# Altium schematic sheet MCU_S32K144.SchDoc
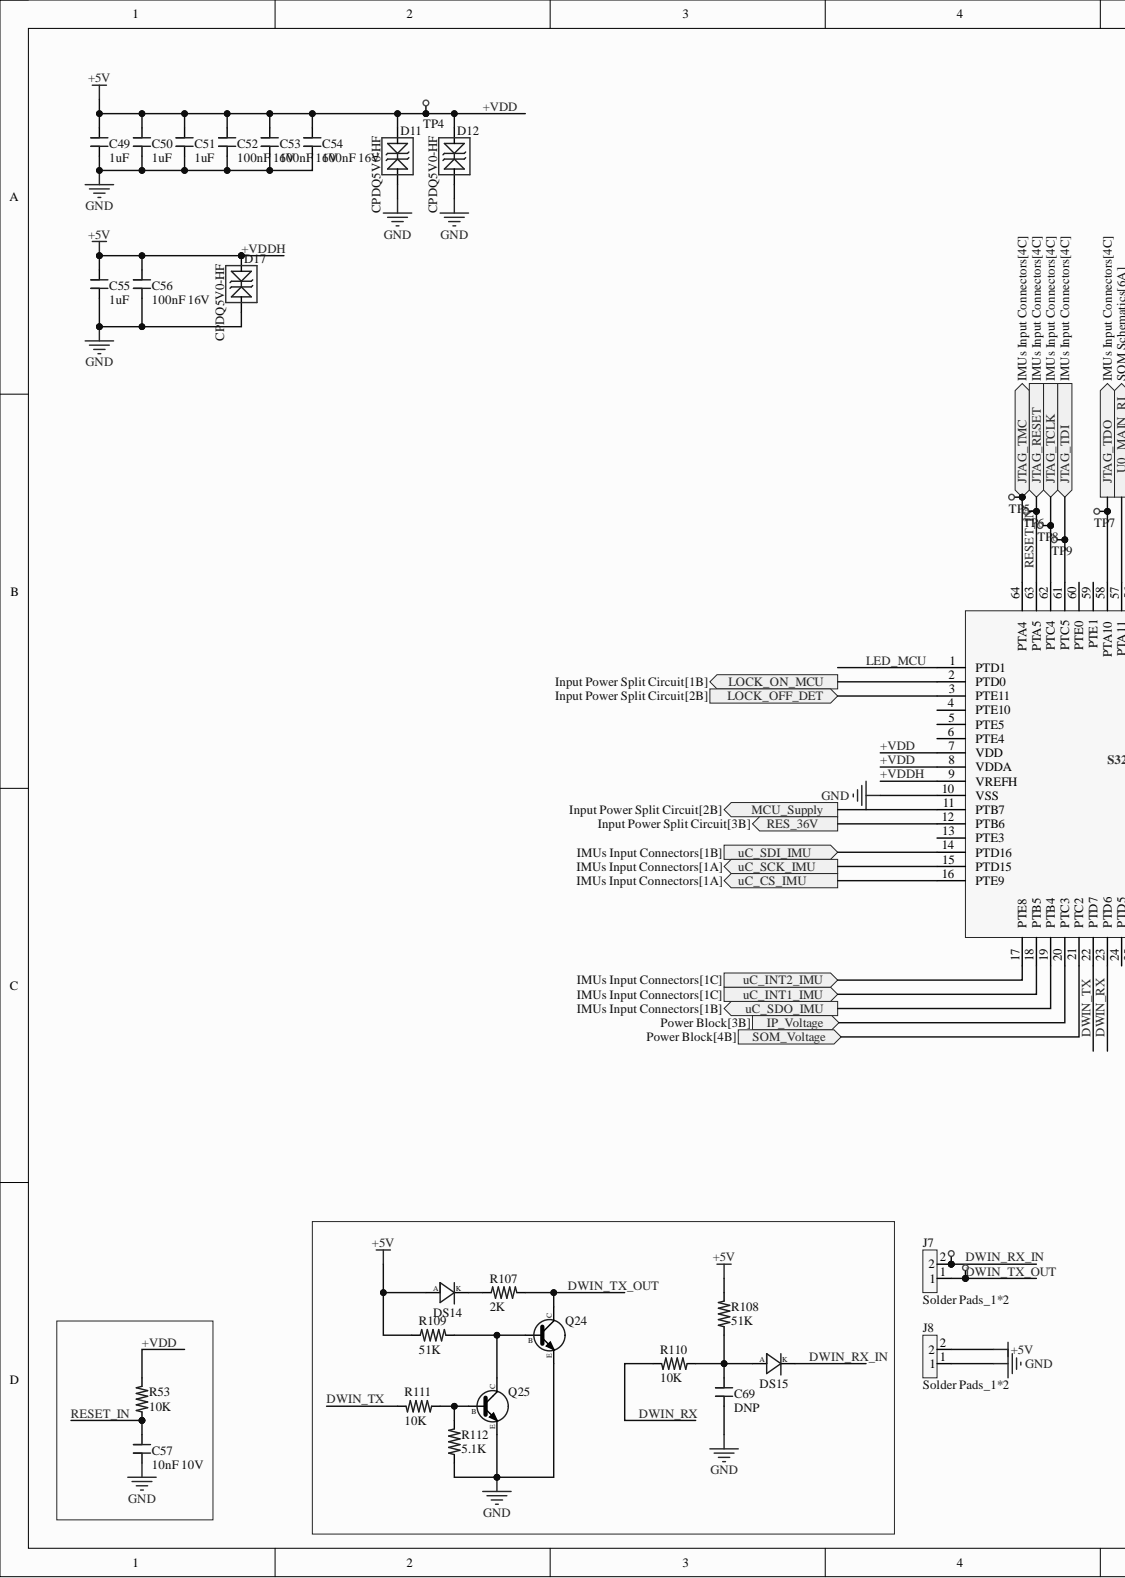
[diagram p0: MCU_S32K144.SchDoc, left]
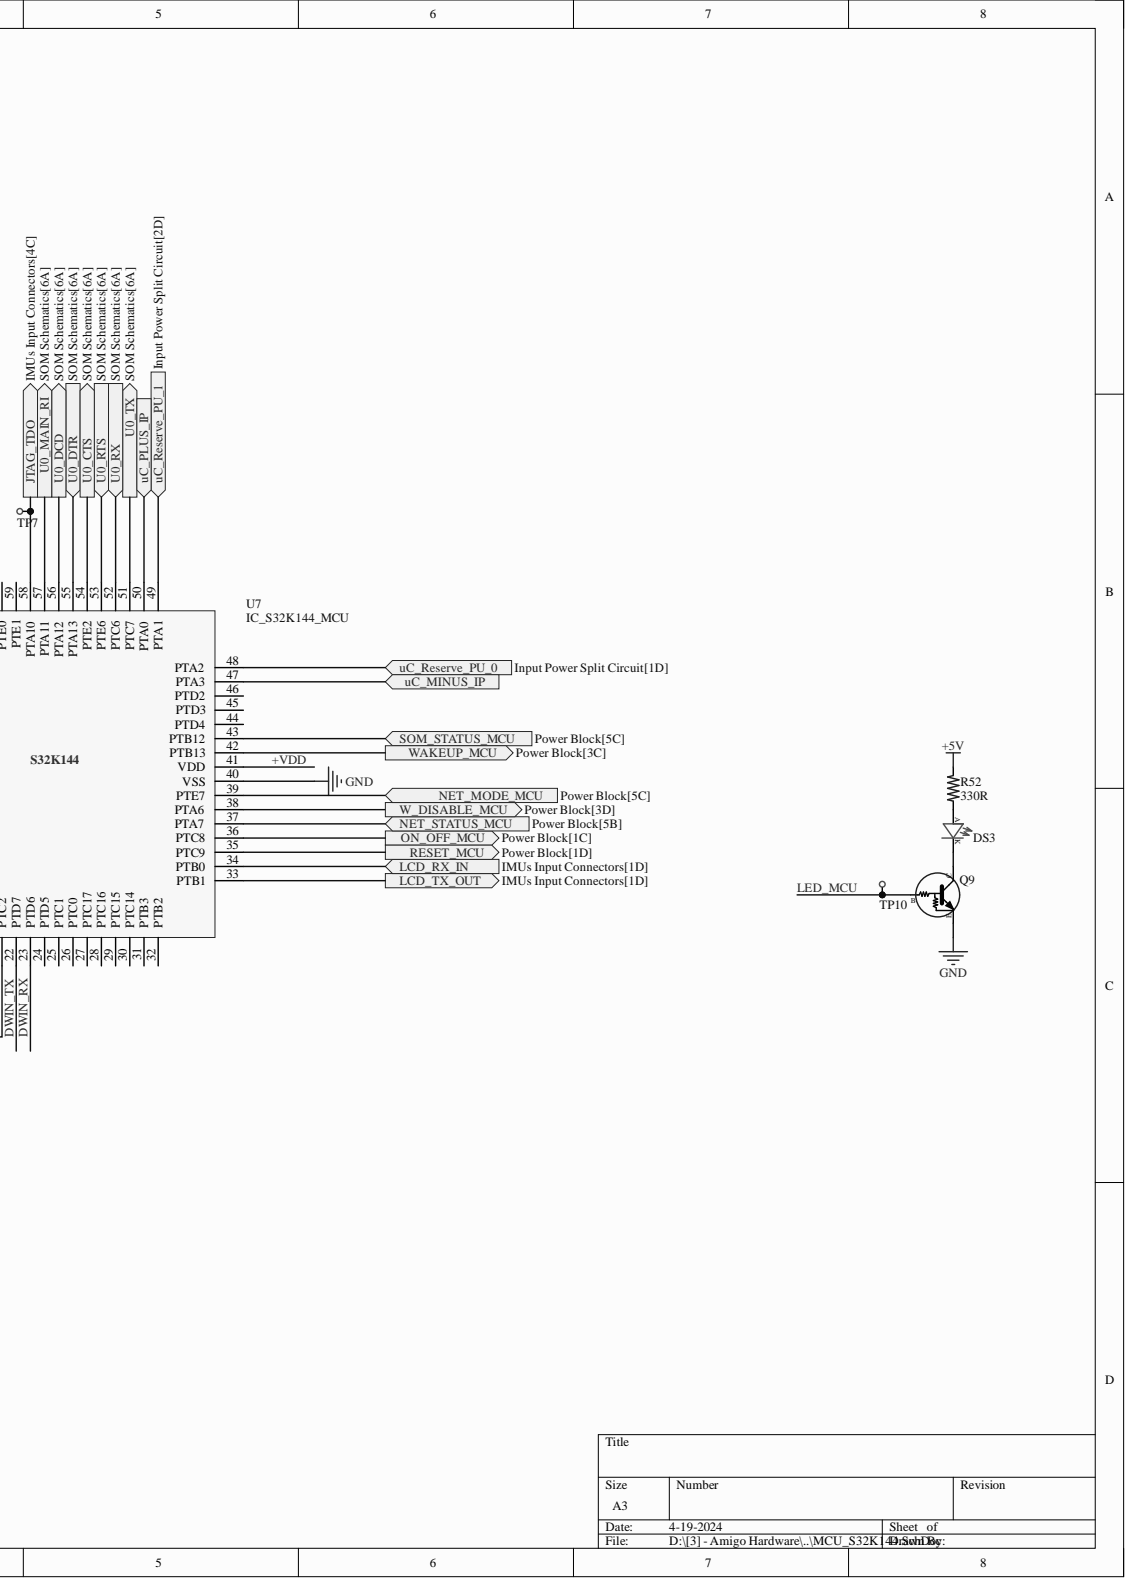
[diagram p1: MCU_S32K144.SchDoc, right]

## Parts
C49  1uF  [CAP_0603]
C50  1uF  [CAP_0603]
C51  1uF  [CAP_0603]
C52  100nF 16V  value 100nF  [CAP_0603]
C53  100nF 16V  value 100nF  Rated DC Voltage (URdc): 16V  [CAP_0603]
C54  100nF 16V  value 100nF  Rated DC Voltage (URdc): 16V  [CAP_0603]
C55  1uF  [CAP_0603]
C56  100nF 16V  value 100nF  [CAP_0603]
C57  10nF 10V  Capacitance: 10nF  Voltage Rating: 10V  [CAP_0603]
C69  DNP  [CAP_0603]  (no BOM)
D11  CPDQ5V0-HF  [SOD-923F]
D12  CPDQ5V0-HF  [SOD-923F]
D17  CPDQ5V0-HF  [SOD-923F]
DS3  HSMS-C190  [LED_0603]  (value not drawn)
DS14  BAS416-HF  [SOD-323]  (value not drawn)
DS15  BAS416-HF  [SOD-323]  (value not drawn)
J7  Solder Pads_1*2  [Solder Pads 2*1]
J8  Solder Pads_1*2  [Solder Pads 2*1]
Q9  DDTC143ZCA-7-F  [SOT23]  (value not drawn)
Q24  MMBT5551LT3G  [SOT23]  (value not drawn)
Q25  MMBT5551LT3G  [SOT23]  (value not drawn)
R52  330R  [RES_0603]
R53  10K  [RES_0603]
R107  2K  [RES_0603]
R108  51K  [RES_0603]
R109  51K  [RES_0603]
R110  10K  [RES_0603]
R111  10K  [RES_0603]
R112  5.1K  [RES_0603]
TP2  Test Point SMD Round 0.8mm  [Test Point Round 0.8mm]  (value not drawn)
TP3  Test Point SMD Round 0.8mm  [Test Point Round 0.8mm]  (value not drawn)
TP4  Test Point SMD Round 0.8mm  [Test Point Round 0.8mm]  (value not drawn)
TP5  Test Point SMD Round 1.27mm  [Test Point Round 1.27mm]  (value not drawn)
TP6  Test Point SMD Round 1.27mm  [Test Point Round 1.27mm]  (value not drawn)
TP7  Test Point SMD Round 1.27mm  [Test Point Round 1.27mm]  (value not drawn)
TP8  Test Point SMD Round 1.27mm  [Test Point Round 1.27mm]  (value not drawn)
TP9  Test Point SMD Round 1.27mm  [Test Point Round 1.27mm]  (value not drawn)
TP10  Test Point SMD Round 0.8mm  [Test Point Round 0.8mm]  (value not drawn)
U7  IC_S32K144_MCU  [LQFP_64]

## Ports
IP_Voltage, JTAG_RESET, JTAG_TCLK, JTAG_TDI, JTAG_TDO, JTAG_TMC, LCD_RX_IN, LCD_TX_OUT, LOCK_OFF_DET, LOCK_ON_MCU, MCU_Supply, NET_MODE_MCU, NET_STATUS_MCU, ON_OFF_MCU, RES_36V, RESET_MCU, SOM_STATUS_MCU, SOM_Voltage, U0_CTS, U0_DCD, U0_DTR, U0_MAIN_RI, U0_RTS, U0_RX, U0_TX, uC_CS_IMU, uC_INT1_IMU, uC_INT2_IMU, uC_MINUS_IP, uC_PLUS_IP, uC_Reserve_PU_0, uC_Reserve_PU_1, uC_SCK_IMU, uC_SDI_IMU, uC_SDO_IMU, W_DISABLE_MCU, WAKEUP_MCU

## Nets
GND: C49.1 C50.1 C51.1 C52.1 C53.1 C54.1 C55.1 C56.1 C57.1 C69.1 D11.1 D12.1 D17.1 J8.1 Q9.2 Q24.2 Q25.2 R112.1 U7.10(VSS) U7.40(VSS)
+VDD: C49.2 C50.2 C51.2 C52.2 C53.2 C54.2 C55.2 C56.2 D11.2 D12.2 D17.2 DS14.1 J8.2 R52.2 R53.2 R108.2 R109.1 TP4.1 U7.7(VDD) U7.8(VDDA) U7.9(VREFH) U7.41(VDD)
DWIN_RX: R110.2 U7.23(PTD6)
DWIN_RX_IN: DS15.2 J7.2 TP2.1
DWIN_TX: R111.2 U7.22(PTD7)
DWIN_TX_OUT: J7.1 Q24.3 R107.2 TP3.1
IP_Voltage: U7.20(PTC3) ; port IP_Voltage
JTAG_TCLK: TP8.1 U7.62(PTC4) ; port JTAG_TCLK
JTAG_TDI: TP9.1 U7.61(PTC5) ; port JTAG_TDI
JTAG_TDO: TP7.1 U7.58(PTA10) ; port JTAG_TDO
JTAG_TMC: TP5.1 U7.64(PTA4) ; port JTAG_TMC
LCD_RX_IN: U7.34(PTB0) ; port LCD_RX_IN
LCD_TX_OUT: U7.33(PTB1) ; port LCD_TX_OUT
LED_MCU: Q9.1 TP10.1 U7.1(PTD1)
LOCK_OFF_DET: U7.3(PTE11) ; port LOCK_OFF_DET
LOCK_ON_MCU: U7.2(PTD0) ; port LOCK_ON_MCU
MCU_Supply: U7.11(PTB7) ; port MCU_Supply
NET_MODE_MCU: U7.39(PTE7) ; port NET_MODE_MCU
NET_STATUS_MCU: U7.37(PTA7) ; port NET_STATUS_MCU
ON_OFF_MCU: U7.36(PTC8) ; port ON_OFF_MCU
RES_36V: U7.12(PTB6) ; port RES_36V
RESET_IN: C57.2 R53.1 TP6.1 U7.63(PTA5) ; port JTAG_RESET
RESET_MCU: U7.35(PTC9) ; port RESET_MCU
SOM_STATUS_MCU: U7.43(PTB12) ; port SOM_STATUS_MCU
SOM_Voltage: U7.21(PTC2) ; port SOM_Voltage
U0_CTS: U7.54(PTE2) ; port U0_CTS
U0_DCD: U7.56(PTA12) ; port U0_DCD
U0_DTR: U7.55(PTA13) ; port U0_DTR
U0_MAIN_RI: U7.57(PTA11) ; port U0_MAIN_RI
U0_RTS: U7.53(PTE6) ; port U0_RTS
U0_RX: U7.52(PTC6) ; port U0_RX
U0_TX: U7.51(PTC7) ; port U0_TX
uC_CS_IMU: U7.16(PTE9) ; port uC_CS_IMU
uC_INT1_IMU: U7.18(PTB5) ; port uC_INT1_IMU
uC_INT2_IMU: U7.17(PTE8) ; port uC_INT2_IMU
uC_MINUS_IP: U7.47(PTA3) ; port uC_MINUS_IP
uC_PLUS_IP: U7.50(PTA0) ; port uC_PLUS_IP
uC_Reserve_PU_0: U7.48(PTA2) ; port uC_Reserve_PU_0
uC_Reserve_PU_1: U7.49(PTA1) ; port uC_Reserve_PU_1
uC_SCK_IMU: U7.15(PTD15) ; port uC_SCK_IMU
uC_SDI_IMU: U7.14(PTD16) ; port uC_SDI_IMU
uC_SDO_IMU: U7.19(PTB4) ; port uC_SDO_IMU
W_DISABLE_MCU: U7.38(PTA6) ; port W_DISABLE_MCU
WAKEUP_MCU: U7.42(PTB13) ; port WAKEUP_MCU
NetC69_2: C69.2 DS15.1 R108.1 R110.1
NetDS3_1: DS3.1 R52.1
NetDS3_2: DS3.2 Q9.3
NetDS14_2: DS14.2 R107.1
NetQ24_1: Q24.1 Q25.3 R109.2
NetQ25_1: Q25.1 R111.1 R112.2

Not connected: U7 4(PTE10) 5(PTE5) 6(PTE4) 13(PTE3) 24(PTD5) 25(PTC1) 26(PTC0) 27(PTC17) 28(PTC16) 29(PTC15) 30(PTC14) 31(PTB3) 32(PTB2) 44(PTD4) 45(PTD3) 46(PTD2) 59(PTE1) 60(PTE0)

## Library data of the parts
C49-C51 C55 = CAP_1uF-16V-10%-0603: Multilayer Ceramic Capacitor, 1 uF, 16 V, ± 10%, X7R, 0603 [1608 Metric]; Manufacturer: Yageo; Manufacturer Part Number: CC0603KRX7R7BB105; Dissipation Factor: 5%; Voltage: 16V; Capacitance: 1uF; Case Code (Imperial): 0603; Case Code (Metric): 1608; Case/Package: 0603; Category: Ceramic Capacitors; Component Kind: Standard; ComponentLink1Description: https://datasheet.ciiva.com/8033/1734573-8033801.pdf?src-supplier=Element14; ComponentLink1URL: https://datasheet.ciiva.com/8033/1734573-8033801.pdf?src-supplier=Element14; ComponentLink2Description: https://datasheet.ciiva.com/8033/1734573-8033800.pdf?src-supplier=Element14; ComponentLink2URL: https://datasheet.ciiva.com/8033/1734573-8033800.pdf?src-supplier=Element14; ComponentLink3Description: https://datasheet.ciiva.com/7978/1489800-7978143.pdf?src-supplier=Element14; ComponentLink3URL: https://datasheet.ciiva.com/7978/1489800-7978143.pdf?src-supplier=Element14; ComponentLink4Description: https://datasheet.ciiva.com/28450/1489800-28450557.pdf?src-supplier=Element14; ComponentLink4URL: https://datasheet.ciiva.com/28450/1489800-28450557.pdf?src-supplier=Element14; ComponentLink5Description: https://datasheet.ciiva.com/176/mlccorderingcode-176789.pdf?src-supplier=Digi-Key; ComponentLink5URL: https://datasheet.ciiva.com/176/mlccorderingcode-176789.pdf?src-supplier=Digi-Key; ComponentLink6Description: https://datasheet.ciiva.com/176/mlccorderingcode-176788.pdf?src-supplier=Digi-Key; ComponentLink6URL: https://datasheet.ciiva.com/176/mlccorderingcode-176788.pdf?src-supplier=Digi-Key; ComponentLink7Description: https://datasheet.ciiva.com/176/mlccorderingcode-176790.pdf?src-supplier=Digi-Key; ComponentLink7URL: https://datasheet.ciiva.com/176/mlccorderingcode-176790.pdf?src-supplier=Digi-Key; ComponentLink8Description: https://datasheet.ciiva.com/5425/upy-gphc-x7r-6-3v-to-50v-8-12304-5425495.pdf?src-supplier=Mouser; ComponentLink8URL: https://datasheet.ciiva.com/5425/upy-gphc-x7r-6-3v-to-50v-8-12304-5425495.pdf?src-supplier=Mouser; Construction: Flat; Depth: 0.8mm; Dielectric: X7R; Halogen Free: Halogen Free; Height: 0.8mm; Lead Free: Lead Free; Length: 1.6mm; Library Name: EM_LIBRARY_1.IntLib; Manufacturer 1: Yageo; Manufacturer Part Number 1: CC0603KRX7R7BB105; Max Operating Temperature: 125°C; Min Operating Temperature: -55°C; Mount: Surface Mount; Number of Pins: 2; Package Quantity: 1; Packaging: Tape and Reel; Pricing 1: 1=0.14, 10=0.098, 50=0.0556, 100=0.0461, 500=0.03234, 1000=0.02678 (USD); Radiation Hardening: No; Reach SVHC Compliant: Unknown; RoHS: Yes; RoHS Compliant: Yes; Series: CC; Stock 1: 9958482; Supplier 1: Digi-Key; Supplier-1: Digikey; Termination: SMD/SMT; Thickness: 0.035inch; Tolerance: 10%; Voltage Rating: 16V; Voltage Rating (DC): 16V; Width: 0.031inch
C52-C54 C56 = CAP_100nF_16V_10%_X7R_0603: Ceramic Capacitor, Multilayer, Ceramic, 16V, 10% +Tol, 10% -Tol, X7R, 15% TC, 0.1uF, Surface Mount, 0603; Manufacturer: KEMET; Manufacturer Part Number: C0603C104K4RACTU; Multilayer: Yes; Capacitor Type: Ceramic; Case/Package: 0603; Category: Ceramic Capacitors; Component Kind: Standard; ComponentLink1Description: https://datasheet.ciiva.com:443/pdfs/VipMasterIC/IC/KEME/KEME-S-A0008991654/KEME-S-A0008991654-1.pdf?src-supplier=IHS+Markit; ComponentLink1URL: https://datasheet.ciiva.com:443/pdfs/VipMasterIC/IC/KEME/KEME-S-A0008991654/KEME-S-A0008991654-1.pdf?src-supplier=IHS+Markit; ComponentLink2Description: https://datasheet.ciiva.com/22749/kem-c1002-x7r-smd-22749657.pdf?src-supplier=Element14; ComponentLink2URL: https://datasheet.ciiva.com/22749/kem-c1002-x7r-smd-22749657.pdf?src-supplier=Element14; ComponentLink3Description: https://datasheet.ciiva.com/14589/70095383-14589014.pdf?src-supplier=Allied+Electronics; ComponentLink3URL: https://datasheet.ciiva.com/14589/70095383-14589014.pdf?src-supplier=Allied+Electronics; ComponentLink4Description: https://datasheet.ciiva.com/5413/kem-c1002-x7r-smd-5413791.pdf?src-supplier=Mouser; ComponentLink4URL: https://datasheet.ciiva.com/5413/kem-c1002-x7r-smd-5413791.pdf?src-supplier=Mouser; Dielectric Material: Ceramic; Height: 0.8mm; JESD-609 Code: e3; Length: 1.6mm; Library Name: EM_LIBRARY_1.IntLib; Max Operating Temperature: 125°C; Min Operating Temperature: -55°C; Mounting Technology: Surface Mount; Package Shape: Rectangular; Package Style: SMTMeter; Packaging: Tape and Reel; Pins: 2; Pricing: 1=0.1, 10=0.069, 50=0.0394, 100=0.0305, 500=0.02298, 1000=0.01805 (USD); Reach SVHC Compliant: Yes; RoHS: Yes; RoHS Compliant: Yes; Stock: 507245; Supplier: Digi-Key; Supplier Part Number: 399-C0603C104K4RAC7867DKR-ND; Temperature Characteristics Code: X7R; Terminal Finish: Nickel; Termination: Wraparound; Tolerance  (fill in as +-): 10%; Tolerance (fill in as +-): 10%; Width: 0.8mm
  C52 C56: Rated DC Voltage (URdc): 16V
C57 = CAP_10nF_10V_10%_X7R_0603: Multilayer Ceramic Capacitor, 0.01 uF, 10 V, ± 10%, X7R, 0603 [1608 Metric]; Manufacturer: KEMET; Manufacturer Part Number: C0603C103K8RACTU; Dissipation Factor: 5%; Case Code (Imperial): 0603; Case Code (Metric): 1608; Case/Package: 0603; Category: Ceramic Capacitors; Component Kind: Standard; ComponentLink1Description: https://datasheet.ciiva.com/49516/1564916-49516523.pdf?src-supplier=Jameco; ComponentLink1URL: https://datasheet.ciiva.com/49516/1564916-49516523.pdf?src-supplier=Jameco; Construction: Flat; Depth: 0.8mm; Dielectric: X7R; Dielectric Material: Ceramic; Height: 0.8mm; Lead Pitch: 0.7mm; Length: 1.6mm; Library Name: EM_LIBRARY_1.IntLib; Manufacturer 1: KEMET; Manufacturer Part Number 1: C0603C103K8RACTU; Max Operating Temperature: 125°C; Min Operating Temperature: -55°C; Mount: Surface Mount; Package Quantity: 1; Packaging: Tape and Reel; Pricing 1: 1=0.1, 10=0.034, 50=0.034, 100=0.02, 1000=0.016, 10000=0.011 (USD); Reach SVHC Compliant: No; RoHS: Yes; Series: -; Stock 1: 6134; Supplier 1: Mouser; Supplier Part Number 1: 80-C0603C103K8R; Supplier-1: Digikey; Termination: SMD/SMT; Thickness: 0.034inch; Tolerance: 10%; Voltage Rating (DC): 10V; Width: 0.031inch
C69 = CAP_NOT-MOUNTED_0603: Do Not Place this Capacitor 0603; Manufacturer: KEMET; Manufacturer Part Number: C0603C103K8RACTU; Capacitance: 10nF; Dielectric: X7R; Dielectric Material: Ceramic; Dissipation Factor: 5%; Tolerance: 10%; Voltage Rating: 10V; Case Code (Imperial): 0603; Case Code (Metric): 1608; Case/Package: 0603; Category: Ceramic Capacitors; ComponentLink1Description: https://datasheet.ciiva.com/49516/1564916-49516523.pdf?src-supplier=Jameco; ComponentLink1URL: https://datasheet.ciiva.com/49516/1564916-49516523.pdf?src-supplier=Jameco; Construction: Flat; Depth: 0.8mm; Height: 0.8mm; Lead Pitch: 0.7mm; Length: 1.6mm; Library Name: EM_LIBRARY_1.IntLib; Manufacturer 1: KEMET; Manufacturer Part Number 1: C0603C103K8RACTU; Max Operating Temperature: 125°C; Min Operating Temperature: -55°C; Mount: Surface Mount; Package Quantity: 1; Packaging: Tape and Reel; Pricing 1: 1=0.1, 10=0.034, 50=0.034, 100=0.02, 1000=0.016, 10000=0.011 (USD); Reach SVHC Compliant: No; RoHS: Yes; Series: -; Stock 1: 6134; Supplier 1: Mouser; Supplier Part Number 1: 80-C0603C103K8R; Supplier-1: Digikey; Termination: SMD/SMT; Thickness: 0.034inch; Voltage Rating (DC): 10V; Width: 0.031inch
D11 D12 D17 = DIO-TVS_5.6V Vbr_18.6V Vc_9.4A Ip_SOD-923: ESD Suppressor Diode TVS Bi-Dir 5V 18.6Vc 2-Pin SOD-923F T/R; Manufacturer: Comchip; Breakdown Voltage: 5.6V; Capacitance: 15pF; Clamping Voltage: 7.3V; Diode Type: ZENER; Number of Bidirectional Channels: 1; Peak Pulse Current: 9.4A; Peak Pulse Power: 174W; Power Line Protection: No; Case/Package: 0402; Category: TVS Diodes; Component Kind: Standard; ComponentLink1Description: https://datasheet.ciiva.com/6577/cpdq5v0-hf20reva825126-6577872.pdf?src-supplier=Digi-Key; ComponentLink1URL: https://datasheet.ciiva.com/6577/cpdq5v0-hf20reva825126-6577872.pdf?src-supplier=Digi-Key; ComponentLink2Description: https://datasheet.ciiva.com/12545/cpdq5v0-hf20reva825126-218795-12545530.pdf?src-supplier=Mouser; ComponentLink2URL: https://datasheet.ciiva.com/12545/cpdq5v0-hf20reva825126-218795-12545530.pdf?src-supplier=Mouser; Library Name: EM_LIBRARY_1.IntLib; Manufacturer 2: Comchip; Manufacturer Part Number: CPDQ5V0-HF; Manufacturer Part Number 2: CPDQ5V0-HF; Max Operating Temperature: 125°C; Min Breakdown Voltage: 5.6V; Min Operating Temperature: -40°C; Mount: Surface Mount; Operating Supply Voltage: 5V; Package Quantity: 8000; Packaging: Tape and Reel; Pricing 1: 1=0.31, 10=0.252, 100=0.1337, 500=0.08794, 1000=0.0598, 2000=0.05394 (USD); Pricing 2: 1=0.31, 10=0.214, 100=0.1042, 500=0.08692, 1000=0.0604, 2000=0.05235 (USD); Reverse Standoff Voltage: 5V; RoHS: Yes; RoHS Compliant: Yes; Series: -; Stock 1: 81421; Stock 2: 80696; Supplier 1: Digi-Key; Supplier 2: Digi-Key; Supplier Part Number 2: 641-1478-1-ND
DS3 = LED_Red_0603_2.2V_HSMS-C190: LED Low-Power Uni-Color Red 630nm 2.1V 2-Pin Chip LED T/R; Manufacturer: Broadcom Avago; Category: LEDs; Component Kind: Standard; Library Name: EM_LIBRARY_1.IntLib; Manufacturer Part Number: HSMS-C190; Pricing 1: 1=0.41, 10=0.272, 100=0.1813, 500=0.12542, 1000=0.10452, 2000=0.09407 (USD); RoHS: Yes; Stock 1: 190765; Supplier 1: Digi-Key; Supplier Part Number 1: 516-1422-1-ND
DS14 DS15 = DIO_REC_100V_200mA_SOD-323: Diode Switching 85V 200MA 250MW; Manufacturer: Comchip; Component Kind: Standard; Library Name: EM_LIBRARY_1.IntLib; Manufacturer Part Number: BAS416-HF; Pricing: 1=0.21, 10=0.146, 100=0.0711, 500=0.0593, 1000=0.04121 (USD); Stock: 2730; Supplier: DigiKey; Supplier Part Number: 641-BAS416-HFCT-ND
J7 J8 = Solder Pads_1*2: Solder Pads Pos 2; Component Kind: Net Tie; Library Name: EM_LIBRARY_1.IntLib
Q9 = TRANS-NPN-BIAS_50V_100mA_250MHz_200mW_SOT23-3: Small Signal Bipolar Transistor, 0.1A I(C), 50V V(BR)CEO, 1-Element, NPN, Silicon; Manufacturer: Diodes; DC Current Gain-Min (hFE): 80; Frequency: 250MHz; Max Collector Current: 100mA; Number of Elements: 1; Package Body Material: Plastic; Power Dissipation-Max (Abs): 200mW; Qualification Status: Not Qualified; Time @ Peak Reflow Temperature-Max (s): 40s; Category: BJTs; Collector-emitter Voltage-Max: 50V; Component Kind: Standard; ComponentLink1Description: https://datasheet.ciiva.com:443/pdfs/VipMasterIC/IC/DIOD/DIODS20605/DIODS20605-1.pdf?src-supplier=IHS+Markit; ComponentLink1URL: https://datasheet.ciiva.com:443/pdfs/VipMasterIC/IC/DIOD/DIODS20605/DIODS20605-1.pdf?src-supplier=IHS+Markit; ComponentLink2Description: http://components.arrow.com/part/dataview/41508542S9348013N2867?src-supplier=Arrow+Electronics; ComponentLink2URL: http://components.arrow.com/part/dataview/41508542S9348013N2867?src-supplier=Arrow+Electronics; ComponentLink3Description: http://www.mouser.com/ds/2/115/ds30330-71322.pdf?src-supplier=Mouser; ComponentLink3URL: http://www.mouser.com/ds/2/115/ds30330-71322.pdf?src-supplier=Mouser; ComponentLink4Description: http://www.alliedelec.com/m/d/be0b5f6f3c5081911d08f51c92d34358.pdf?src-supplier=Allied+Electronics; ComponentLink4URL: http://www.alliedelec.com/m/d/be0b5f6f3c5081911d08f51c92d34358.pdf?src-supplier=Allied+Electronics; ComponentLink5Description: http://uk.rs-online.com/webdocs/1321/0900766b81321d3c.pdf?src-supplier=Rs; ComponentLink5URL: http://uk.rs-online.com/webdocs/1321/0900766b81321d3c.pdf?src-supplier=Rs; ComponentLink6Description: https://www.diodes.com/assets/Datasheets/ds30330.pdf?src-supplier=Digi-Key; ComponentLink6URL: https://www.diodes.com/assets/Datasheets/ds30330.pdf?src-supplier=Digi-Key; ComponentLink7Description: https://datasheet.octopart.com/DDTC143ZCA-7-F-Diodes-Inc.-datasheet-46751235.pdf?src-supplier=Farnell; ComponentLink7URL: https://datasheet.octopart.com/DDTC143ZCA-7-F-Diodes-Inc.-datasheet-46751235.pdf?src-supplier=Farnell; ComponentLink8Description: http://www.diodes.com//datasheets/ds30330.pdf?src-supplier=Avnet; ComponentLink8URL: http://www.diodes.com//datasheets/ds30330.pdf?src-supplier=Avnet; ComponentLink9Description: http://www.diodes.com/_files/datasheets/ds30330.pdf?src-supplier=Future+Electronics; ComponentLink9URL: http://www.diodes.com/_files/datasheets/ds30330.pdf?src-supplier=Future+Electronics; JESD-30 Code: R-PDSO-G3; JESD-609 Code: e3; Library Name: EM_LIBRARY_1.IntLib; Manufacturer Part Number: DDTC143ZCA-7-F; Max Operating Temperature: 150°C; Moisture Sensitivity Level: 1; Package Shape: Rectangular; Package Style: SMALL OUTLINEMeter; Peak Reflow Temperature (Cel): 260°C; Pins: 3; Polarity/Channel Type: NPN; Pricing: 1=0.19, 10=0.136, 100=0.0733, 500=0.0576, 1000=0.04 (USD); Reach SVHC Compliant: Yes; RoHS: Yes; RoHS Compliant: Yes; Stock: 1386439; Supplier: Digi-Key; Supplier Part Number: DDTC143ZCA-FDICT-ND; Surface Mount: Yes; Terminal Finish: Tin; Terminal Form: Gull Wing; Terminal Position: DUAL; Transistor Element Material: SILICON
Q24 Q25 = TRANS_NPN_160V_600mA_SOT23: NPN Ic=600mA Vceo=160V hfe=80~250 P=225mW SOT-23; Manufacturer: ON Semiconductor; Collector Base Voltage (VCBO): 180V; Collector Emitter Breakdown Voltage: 160V; Collector Emitter Saturation Voltage: 150mV; Collector-emitter Voltage-Max: 200mV; Current Rating: 600mA; Emitter Base Voltage (VEBO): 6V; hFE Min: 80; Max Power Dissipation: 225mW; Number of Elements: 1; Power Dissipation: 300mW; Case/Package: SOT-23-3; Category: BJTs; Collector Emitter Voltage (VCEO): 160V; Component Kind: Standard; ComponentLink1Description: https://datasheet.ciiva.com/4721/mmbt5550lt1-d-111393-4721331.pdf?src-supplier=Mouser; ComponentLink1URL: https://datasheet.ciiva.com/4721/mmbt5550lt1-d-111393-4721331.pdf?src-supplier=Mouser; ComponentLink2Description: https://datasheet.ciiva.com/15138/getdatasheetpartid-69307-15138969.pdf?src-supplier=Verical; ComponentLink2URL: https://datasheet.ciiva.com/15138/getdatasheetpartid-69307-15138969.pdf?src-supplier=Verical; ComponentLink3Description: https://datasheet.ciiva.com/46624/mmbt5550lt1-d-46624373.pdf?src-supplier=Digi-Key; ComponentLink3URL: https://datasheet.ciiva.com/46624/mmbt5550lt1-d-46624373.pdf?src-supplier=Digi-Key; Height: 1.11mm; Lead Free: Lead Free; Length: 3.04mm; Library Name: EM_LIBRARY_1.IntLib; Manufacturer Part Number: MMBT5551LT3G; Max Breakdown Voltage: 160V; Max Collector Current: 600mA; Max Operating Temperature: 150°C; Min Operating Temperature: -55°C; Number of Pins: 3; Package Quantity: 10000; Packaging: Tape and Reel; Polarity: NPN; Pricing 1: 1=0.17, 10=0.12, 100=0.065, 500=0.05104, 1000=0.03545, 2000=0.02937, 5000=0.02777 (USD); Radiation Hardening: No; RoHS: Yes; RoHS Compliant: Yes; Series: -; Stock 1: 6650; Supplier 1: Digi-Key; Supplier Part Number 1: MMBT5551LT3GOSCT-ND; Voltage Rating (DC): 160V; Width: 2.64mm
R52 = RES_330R_0.1W_1%_0603: Fixed Resistor, Metal Glaze/thick Film, 0.1W, 330ohm, 75V, 1% +/-Tol, 100ppm/Cel, Surface Mount, 0603; Manufacturer: Yageo; Manufacturer Part Number: RC0603FR-13330RL; Additional Feature: LASER TRIMMABLE; Package Height: 0.45mm; Package Length: 1.6mm; Package Width: 0.8mm; Power: 100mW; Rated Temperature: 70°C; Temperature Coefficient: 100ppm/°C; Case/Package: 0603; Category: Chip SMD Resistors; Component Kind: Standard; ComponentLink1Description: https://datasheet.ciiva.com:443/pdfs/VipMasterIC/IC/YAGO/YAGO-S-A0008145938/YAGO-S-A0008145938-1.pdf?src-supplier=IHS+Markit; ComponentLink1URL: https://datasheet.ciiva.com:443/pdfs/VipMasterIC/IC/YAGO/YAGO-S-A0008145938/YAGO-S-A0008145938-1.pdf?src-supplier=IHS+Markit; ComponentLink2Description: https://datasheet.ciiva.com/12561/rc0603-272842-12561430.pdf?src-supplier=Mouser; ComponentLink2URL: https://datasheet.ciiva.com/12561/rc0603-272842-12561430.pdf?src-supplier=Mouser; Construction: Chip; JESD-609 Code: e3; Library Name: EM_LIBRARY_1.IntLib; Max Operating Temperature: 155°C; Min Operating Temperature: -55°C; Mounting Technology: Surface Mount; Package Shape: Rectangular; Package Style: SMTMeter; Packaging: Tape and Reel; Pins: 2; Pricing: 1=0.1, 10=0.019, 50=0.0112, 100=0.0093, 500=0.00622, 1000=0.00532, 5000=0.00382, 10000=0.00335 (USD); Reach SVHC Compliant: Yes; Resistor Type: FIXED RESISTOR; RoHS: Yes; RoHS Compliant: Yes; Stock: 32231; Supplier: Digi-Key; Supplier Part Number: 13-RC0603FR-13330RLCT-ND; Technology: METAL GLAZE/THICK FILM; Terminal Finish: Nickel; Termination: Wraparound; Tolerance: 1%; Value: 330R; Working Voltage: 75V
R53 R110 R111 = RES_10K_0.1W_1%_0603: Fixed Resistor, Metal Glaze/thick Film, 0.1W, 10000ohm, 75V, 1% +/-Tol, 100ppm/Cel, Surface Mount, 0603; Manufacturer: Yageo; Manufacturer Part Number: RC0603FR-0710KL; Additional Feature: LASER TRIMMABLE; Package Height: 0.45mm; Package Length: 1.6mm; Package Width: 0.8mm; Power: 100mW; Rated Temperature: 70°C; Temperature Coefficient: 100ppm/°C; Value: 10kR; Case/Package: 0603; Category: Chip SMD Resistors; Component Kind: Standard; ComponentLink1Description: https://datasheet.ciiva.com:443/pdfs/VipMasterIC/IC/YAGO/YAGO-S-A0008145938/YAGO-S-A0008145938-1.pdf?src-supplier=IHS+Markit; ComponentLink1URL: https://datasheet.ciiva.com:443/pdfs/VipMasterIC/IC/YAGO/YAGO-S-A0008145938/YAGO-S-A0008145938-1.pdf?src-supplier=IHS+Markit; ComponentLink2Description: https://datasheet.ciiva.com/46440/1868787-46440891.pdf?src-supplier=Element14; ComponentLink2URL: https://datasheet.ciiva.com/46440/1868787-46440891.pdf?src-supplier=Element14; ComponentLink3Description: https://datasheet.ciiva.com/7746/1738695-7746239.pdf?src-supplier=Element14; ComponentLink3URL: https://datasheet.ciiva.com/7746/1738695-7746239.pdf?src-supplier=Element14; ComponentLink4Description: https://datasheet.ciiva.com/7983/443971-7983596.pdf?src-supplier=Element14; ComponentLink4URL: https://datasheet.ciiva.com/7983/443971-7983596.pdf?src-supplier=Element14; ComponentLink5Description: https://datasheet.ciiva.com/7660/38590-7660789.pdf?src-supplier=Element14; ComponentLink5URL: https://datasheet.ciiva.com/7660/38590-7660789.pdf?src-supplier=Element14; ComponentLink6Description: https://datasheet.ciiva.com/22747/443971-22747519.pdf?src-supplier=Element14; ComponentLink6URL: https://datasheet.ciiva.com/22747/443971-22747519.pdf?src-supplier=Element14; ComponentLink7Description: https://datasheet.ciiva.com/15189/getdatasheetpartid-409289-15189591.pdf?src-supplier=Verical; ComponentLink7URL: https://datasheet.ciiva.com/15189/getdatasheetpartid-409289-15189591.pdf?src-supplier=Verical; Construction: Chip; JESD-609 Code: e3; Library Name: EM_LIBRARY_1.IntLib; Max Operating Temperature: 155°C; Min Operating Temperature: -55°C; Mounting Technology: Surface Mount; Package Shape: Rectangular; Package Style: SMTMeter; Packaging: Tape and Reel; Pins: 2; Pricing: 1=0.1, 10=0.019, 50=0.0112, 100=0.0093, 500=0.00622, 1000=0.00532 (USD); Reach SVHC Compliant: Yes; Resistor Type: FIXED RESISTOR; RoHS: Yes; RoHS Compliant: Yes; Stock: 24542638; Supplier: Digi-Key; Supplier Part Number: 311-10.0KHRDKR-ND; Technology: METAL GLAZE/THICK FILM; Terminal Finish: Nickel; Termination: Wraparound; Tolerance: 1%; Working Voltage: 75V
R107 = RES_2K-0.1W-1%-0603: Fixed Resistor, Metal Glaze/thick Film, 0.1W, 2000ohm, 75V, 1% +/-Tol, 100ppm/Cel, Surface Mount, 0603; Manufacturer: Yageo; Manufacturer Part Number: RC0603FR-072KL; Additional Feature: LASER TRIMMABLE; Package Height: 0.45mm; Package Length: 1.6mm; Package Width: 0.8mm; Power: 100mW; Rated Temperature: 70°C; Temperature Coefficient: 100ppm/°C; Value: 2kR; Case/Package: 0603; Category: Chip SMD Resistors; Component Kind: Standard; ComponentLink1Description: https://datasheet.ciiva.com:443/pdfs/VipMasterIC/IC/YAGO/YAGO-S-A0008145938/YAGO-S-A0008145938-1.pdf?src-supplier=IHS+Markit; ComponentLink1URL: https://datasheet.ciiva.com:443/pdfs/VipMasterIC/IC/YAGO/YAGO-S-A0008145938/YAGO-S-A0008145938-1.pdf?src-supplier=IHS+Markit; ComponentLink2Description: https://datasheet.ciiva.com/11808/0900766b80f96399-11808134.pdf?src-supplier=Rs; ComponentLink2URL: https://datasheet.ciiva.com/11808/0900766b80f96399-11808134.pdf?src-supplier=Rs; ComponentLink3Description: https://datasheet.ciiva.com/22747/443971-22747526.pdf?src-supplier=Element14; ComponentLink3URL: https://datasheet.ciiva.com/22747/443971-22747526.pdf?src-supplier=Element14; ComponentLink4Description: https://datasheet.ciiva.com/2453/pyu-r-int-thick-7-2453914.pdf?src-supplier=Digikey; ComponentLink4URL: https://datasheet.ciiva.com/2453/pyu-r-int-thick-7-2453914.pdf?src-supplier=Digikey; ComponentLink5Description: https://datasheet.ciiva.com/2453/pyu-r-int-thick-7-2453915.pdf?src-supplier=Digikey; ComponentLink5URL: https://datasheet.ciiva.com/2453/pyu-r-int-thick-7-2453915.pdf?src-supplier=Digikey; ComponentLink6Description: https://datasheet.ciiva.com/2453/pyu-r-int-thick-7-2453913.pdf?src-supplier=Digikey; ComponentLink6URL: https://datasheet.ciiva.com/2453/pyu-r-int-thick-7-2453913.pdf?src-supplier=Digikey; ComponentLink7Description: https://datasheet.ciiva.com/12555/rc0603-272842-12555257.pdf?src-supplier=Mouser; ComponentLink7URL: https://datasheet.ciiva.com/12555/rc0603-272842-12555257.pdf?src-supplier=Mouser; Construction: Chip; JESD-609 Code: e3; Library Name: EM_LIBRARY_1.IntLib; Max Operating Temperature: 155°C; Min Operating Temperature: -55°C; Mounting Technology: Surface Mount; Package Shape: Rectangular; Package Style: SMTMeter; Packaging: Tape and Reel; Pins: 2; Pricing: 5000=0.00382, 10000=0.00335, 25000=0.00287, 35000=0.00278, 50000=0.00257, 125000=0.00232 (USD); Reach SVHC Compliant: Yes; Resistor Type: FIXED RESISTOR; RoHS: Yes; RoHS Compliant: Yes; Stock: 703624; Supplier: Digikey; Supplier Part Number: 311-2.00KHRTR-ND; Technology: METAL GLAZE/THICK FILM; Terminal Finish: Nickel; Termination: Wraparound; Tolerance: 1%; Working Voltage: 75V
R108 R109 = RES_51K_0.1W_1%_0603: Fixed Resistor, Metal Glaze/thick Film, 0.1W, 51000ohm, 75V, 1% +/-Tol, 100ppm/Cel, Surface Mount, 0603; Manufacturer: Yageo; Manufacturer Part Number: RC0603FR-0751KL; Additional Feature: LASER TRIMMABLE; Package Height: 0.45mm; Package Length: 1.6mm; Package Width: 0.8mm; Power: 100mW; Rated Temperature: 70°C; Temperature Coefficient: 100ppm/°C; Value: 51kR; Case/Package: 0603; Category: Chip SMD Resistors; Component Kind: Standard; ComponentLink1Description: https://datasheet.ciiva.com:443/pdfs/VipMasterIC/IC/YAGO/YAGO-S-A0008145938/YAGO-S-A0008145938-1.pdf?src-supplier=IHS+Markit; ComponentLink1URL: https://datasheet.ciiva.com:443/pdfs/VipMasterIC/IC/YAGO/YAGO-S-A0008145938/YAGO-S-A0008145938-1.pdf?src-supplier=IHS+Markit; ComponentLink2Description: https://datasheet.ciiva.com/11819/0900766b80f96399-11819378.pdf?src-supplier=Rs; ComponentLink2URL: https://datasheet.ciiva.com/11819/0900766b80f96399-11819378.pdf?src-supplier=Rs; ComponentLink3Description: https://datasheet.ciiva.com/46395/443971-46395014.pdf?src-supplier=Element14; ComponentLink3URL: https://datasheet.ciiva.com/46395/443971-46395014.pdf?src-supplier=Element14; ComponentLink4Description: https://datasheet.ciiva.com/2454/pyu-r-int-thick-7-2454124.pdf?src-supplier=Digi-Key; ComponentLink4URL: https://datasheet.ciiva.com/2454/pyu-r-int-thick-7-2454124.pdf?src-supplier=Digi-Key; ComponentLink5Description: https://datasheet.ciiva.com/2454/pyu-r-int-thick-7-2454125.pdf?src-supplier=Digi-Key; ComponentLink5URL: https://datasheet.ciiva.com/2454/pyu-r-int-thick-7-2454125.pdf?src-supplier=Digi-Key; ComponentLink6Description: https://datasheet.ciiva.com/2454/pyu-r-int-thick-7-2454123.pdf?src-supplier=Digi-Key; ComponentLink6URL: https://datasheet.ciiva.com/2454/pyu-r-int-thick-7-2454123.pdf?src-supplier=Digi-Key; ComponentLink7Description: https://datasheet.ciiva.com/12558/rc0603-272842-12558554.pdf?src-supplier=Mouser; ComponentLink7URL: https://datasheet.ciiva.com/12558/rc0603-272842-12558554.pdf?src-supplier=Mouser; Construction: Chip; JESD-609 Code: e3; Library Name: EM_LIBRARY_1.IntLib; Max Operating Temperature: 155°C; Min Operating Temperature: -55°C; Mounting Technology: Surface Mount; Package Shape: Rectangular; Package Style: SMTMeter; Packaging: Tape and Reel; Pins: 2; Pricing: 1=0.1, 10=0.019, 50=0.0112, 100=0.0093, 500=0.00622, 1000=0.00532 (USD); Reach SVHC Compliant: Yes; Resistor Type: FIXED RESISTOR; RoHS: Yes; RoHS Compliant: Yes; Stock: 1453302; Supplier: Digi-Key; Supplier Part Number: 311-51.0KHRCT-ND; Technology: METAL GLAZE/THICK FILM; Terminal Finish: Nickel; Termination: Wraparound; Tolerance: 1%; Working Voltage: 75V
R112 = RES_5.1K_1/10W_5%_0603: SMD Chip Resistor, 5.1 kOhm, ± 5%, 100 mW, 0603 [1608 Metric], Thick Film, General Purpose; Manufacturer 1: Yageo; Manufacturer Part Number 1: RC0603JR-075K1L; Category: Chip SMD Resistors; Component Kind: Standard; ComponentLink1Description: http://www.farnell.com/datasheets/2167052.pdf?src-supplier=Farnell; ComponentLink1URL: http://www.farnell.com/datasheets/2167052.pdf?src-supplier=Farnell; ComponentLink2Description: http://www.mouser.com/ds/2/447/RC0603-272842.pdf?src-supplier=Mouser; ComponentLink2URL: http://www.mouser.com/ds/2/447/RC0603-272842.pdf?src-supplier=Mouser; ComponentLink3Description: http://www.farnell.com/datasheets/2167052.pdf?src-supplier=Element14; ComponentLink3URL: http://www.farnell.com/datasheets/2167052.pdf?src-supplier=Element14; ComponentLink4Description: http://www.farnell.com/datasheets/1868787.pdf?src-supplier=Newark; ComponentLink4URL: http://www.farnell.com/datasheets/1868787.pdf?src-supplier=Newark; ComponentLink5Description: https://datasheet.octopart.com/RC0603JR-075K1L-Yageo-datasheet-34211833.pdf?src-supplier=Element14; ComponentLink5URL: https://datasheet.octopart.com/RC0603JR-075K1L-Yageo-datasheet-34211833.pdf?src-supplier=Element14; ComponentLink6Description: https://datasheet.octopart.com/RC0603JR-075K1L-Yageo-datasheet-62067350.pdf?src-supplier=Farnell; ComponentLink6URL: https://datasheet.octopart.com/RC0603JR-075K1L-Yageo-datasheet-62067350.pdf?src-supplier=Farnell; ComponentLink7Description: http://www.farnell.com/datasheets/2167052.pdf?src-supplier=Newark; ComponentLink7URL: http://www.farnell.com/datasheets/2167052.pdf?src-supplier=Newark; ComponentLink8Description: http://www.yageo.com/documents/recent/PYu-RC_Group_51_RoHS_L_10.pdf?src-supplier=Digi-Key; ComponentLink8URL: http://www.yageo.com/documents/recent/PYu-RC_Group_51_RoHS_L_10.pdf?src-supplier=Digi-Key; ComponentLink9Description: https://datasheet.octopart.com/RC0603JR-075K1L-Yageo-datasheet-164759695.pdf?src-supplier=Future+Electronics; ComponentLink9URL: https://datasheet.octopart.com/RC0603JR-075K1L-Yageo-datasheet-164759695.pdf?src-supplier=Future+Electronics; ComponentLink10Description: http://www.yageo.com/documents/recent/PYu-RC0603_51_RoHS_L_7.pdf?src-supplier=Future+Electronics; ComponentLink10URL: http://www.yageo.com/documents/recent/PYu-RC0603_51_RoHS_L_7.pdf?src-supplier=Future+Electronics; Library Name: EM_LIBRARY_1.IntLib; Pricing 1: 1=0.1, 10=0.014, 50=0.0088, 100=0.0073, 500=0.00486, 1000=0.00415 (USD); RoHS: Yes; Stock 1: 372455; Supplier 1: Digi-Key; Supplier Part Number 1: 311-5.1KGRCT-ND
TP2-TP4 TP10 = Test Point SMD Round 0.8mm: Testpoint SMD Round; Component Kind: Net Tie; Library Name: EM_LIBRARY_1.IntLib
TP5-TP9 = Test Point SMD Round 1.27mm: Testpoint SMD Round; Component Kind: Net Tie; Library Name: EM_LIBRARY_1.IntLib
U7 = IC_S32K144_MCU: Component Kind: Standard; Library Name: EM_LIBRARY_1.IntLib
